# Altium schematic sheet Circuit_Design.SchDoc
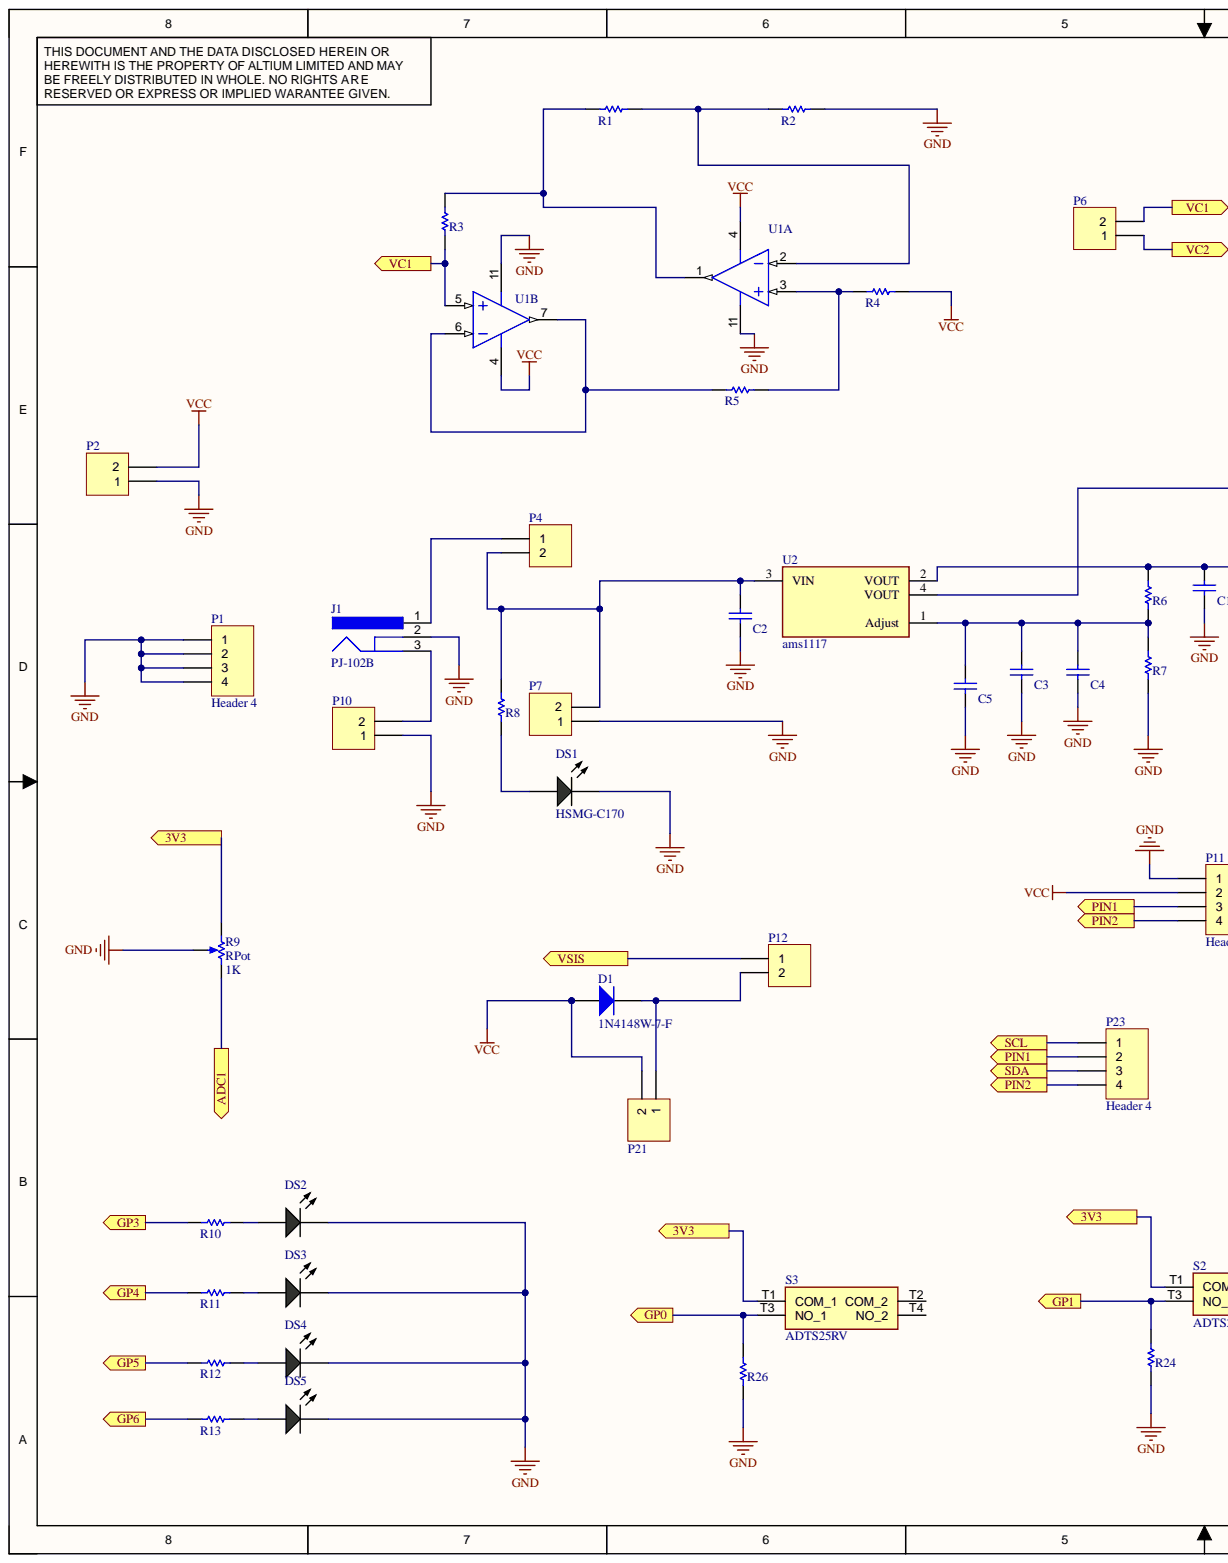
[diagram p0: Circuit_Design.SchDoc, left]
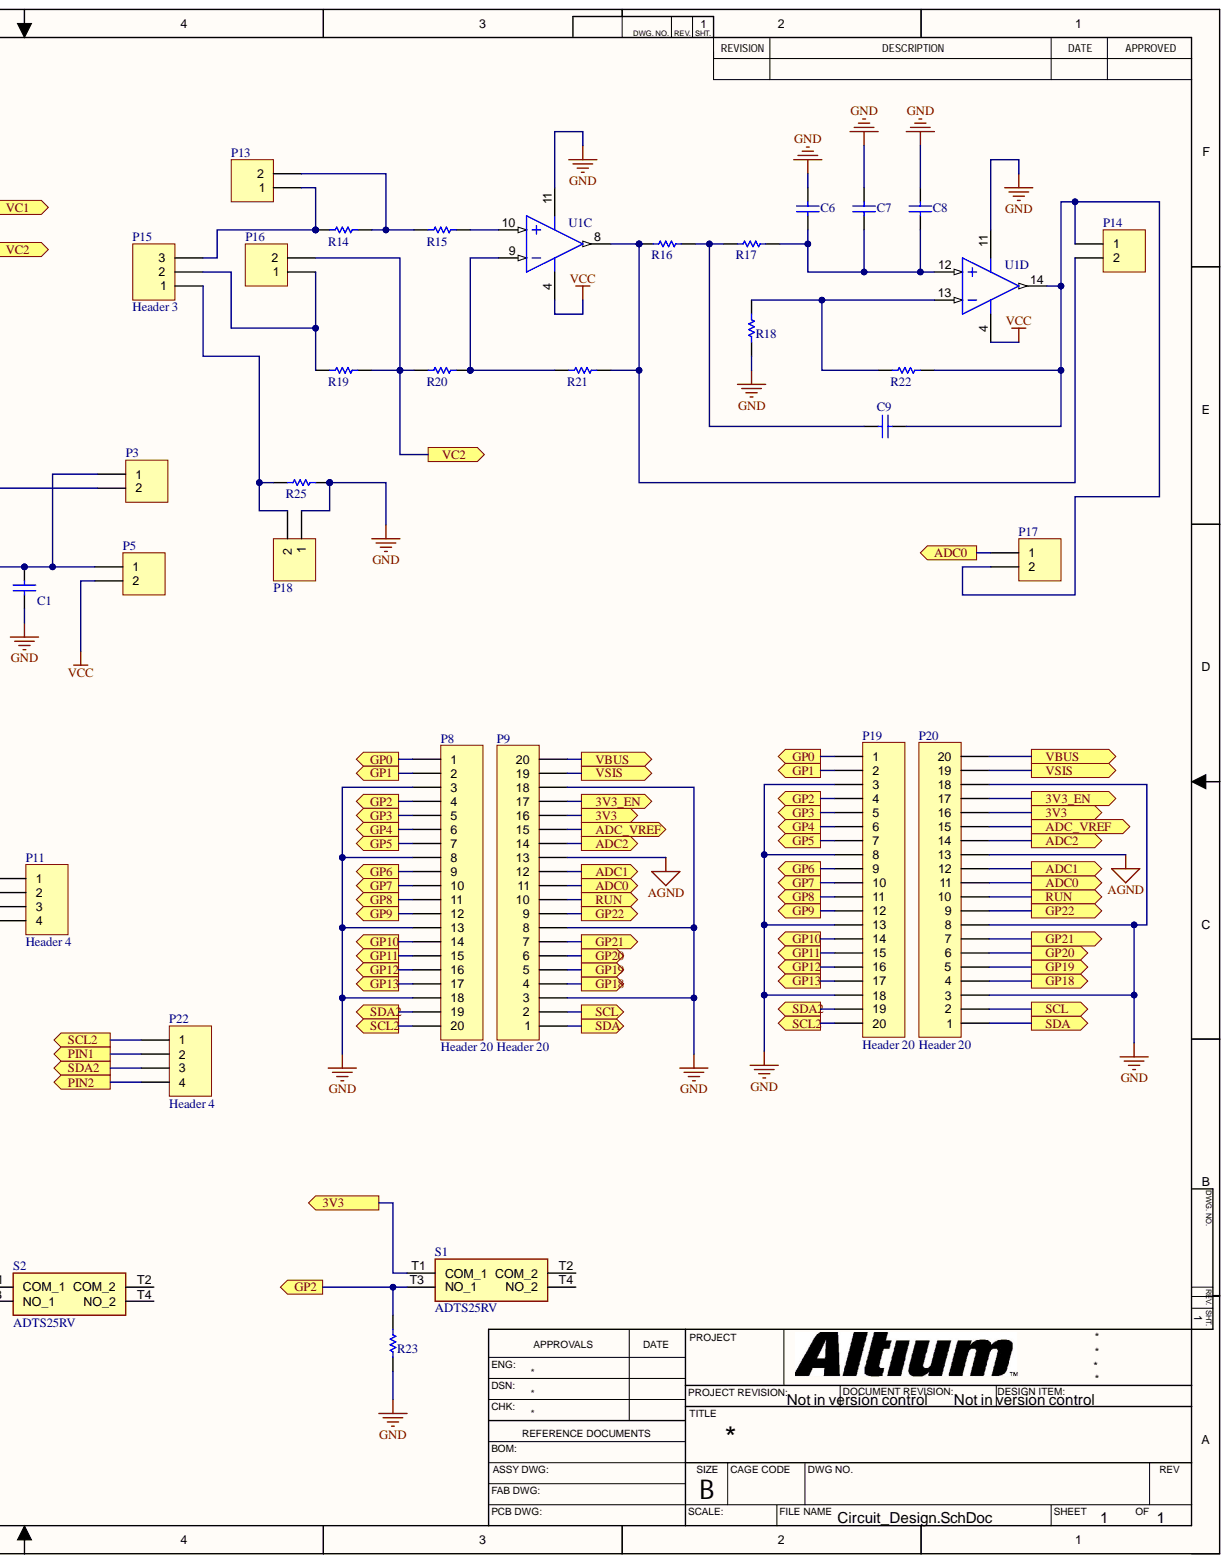
[diagram p1: Circuit_Design.SchDoc, right]

## Parts
C1  C0805C105K3RACTU  [CAPC2013X140X50LL20T25]  (value not drawn)
C2  C0805C105K3RACTU  [CAPC2013X140X50LL20T25]  (value not drawn)
C3  C0805C105K3RACTU  [CAPC2013X140X50LL20T25]  (value not drawn)
C4  C0805C105K3RACTU  [CAPC2013X140X50LL20T25]  (value not drawn)
C5  C0805C105K3RACTU  [CAPC2013X140X50LL20T25]  (value not drawn)
C6  C0805C105K3RACTU  [CAPC2013X140X50LL20T25]  (value not drawn)
C7  C0805C105K3RACTU  [CAPC2013X140X50LL20T25]  (value not drawn)
C8  C0805C105K3RACTU  [CAPC2013X140X50LL20T25]  (value not drawn)
C9  C0805C105K3RACTU  [CAPC2013X140X50LL20T25]  (value not drawn)
D1  1N4148W-7-F  [DIOD-SOD123-2_V]
DS1  HSMG-C170  [AVAG-HSMX-C170_V]
DS2  HSMG-C170  [AVAG-HSMX-C170_V]
DS3  HSMG-C170  [AVAG-HSMX-C170_V]
DS4  HSMG-C170  [AVAG-HSMX-C170_V]
DS5  HSMG-C170  [AVAG-HSMX-C170_V]
J1  PJ-102B  [CUI-PJ-102B_V]
P1  Header 4  [HDR1X4]
P2  Header 2  [HDR1X2]  (value not drawn)
P3  Header 2  [HDR1X2]  (value not drawn)
P4  Header 2  [HDR1X2]  (value not drawn)
P5  Header 2  [HDR1X2]  (value not drawn)
P6  Header 2  [HDR1X2]  (value not drawn)
P7  Header 2  [HDR1X2]  (value not drawn)
P8  Header 20  [HDR1X20]
P9  Header 20  [HDR1X20]
P10  Header 2  [HDR1X2]  (value not drawn)
P11  Header 4  [HDR1X4]
P12  Header 2  [HDR1X2]  (value not drawn)
P13  Header 2  [HDR1X2]  (value not drawn)
P14  Header 2  [HDR1X2]  (value not drawn)
P15  Header 3  [HDR1X3]
P16  Header 2  [HDR1X2]  (value not drawn)
P17  Header 2  [HDR1X2]  (value not drawn)
P18  Header 2  [HDR1X2]  (value not drawn)
P19  Header 20  [HDR1X20]
P20  Header 20  [HDR1X20]
P21  Header 2  [HDR1X2]  (value not drawn)
P22  Header 4  [HDR1X4]
P23  Header 4  [HDR1X4]
R1  CRCW08050000Z0EA  [RESC2013X50X30LL20T20]  (value not drawn)
R2  CRCW08050000Z0EA  [RESC2013X50X30LL20T20]  (value not drawn)
R3  CRCW08050000Z0EA  [RESC2013X50X30LL20T20]  (value not drawn)
R4  CRCW08050000Z0EA  [RESC2013X50X30LL20T20]  (value not drawn)
R5  CRCW08050000Z0EA  [RESC2013X50X30LL20T20]  (value not drawn)
R6  CRCW08050000Z0EA  [RESC2013X50X30LL20T20]  (value not drawn)
R7  CRCW08050000Z0EA  [RESC2013X50X30LL20T20]  (value not drawn)
R8  CRCW08050000Z0EA  [RESC2013X50X30LL20T20]  (value not drawn)
R9  RPot  value 1K  [VR5]
R10  CRCW08050000Z0EA  [RESC2013X50X30LL20T20]  (value not drawn)
R11  CRCW08050000Z0EA  [RESC2013X50X30LL20T20]  (value not drawn)
R12  CRCW08050000Z0EA  [RESC2013X50X30LL20T20]  (value not drawn)
R13  CRCW08050000Z0EA  [RESC2013X50X30LL20T20]  (value not drawn)
R14  CRCW08050000Z0EA  [RESC2013X50X30LL20T20]  (value not drawn)
R15  CRCW08050000Z0EA  [RESC2013X50X30LL20T20]  (value not drawn)
R16  CRCW08050000Z0EA  [RESC2013X50X30LL20T20]  (value not drawn)
R17  CRCW08050000Z0EA  [RESC2013X50X30LL20T20]  (value not drawn)
R18  CRCW08050000Z0EA  [RESC2013X50X30LL20T20]  (value not drawn)
R19  CRCW08050000Z0EA  [RESC2013X50X30LL20T20]  (value not drawn)
R20  CRCW08050000Z0EA  [RESC2013X50X30LL20T20]  (value not drawn)
R21  CRCW08050000Z0EA  [RESC2013X50X30LL20T20]  (value not drawn)
R22  CRCW08050000Z0EA  [RESC2013X50X30LL20T20]  (value not drawn)
R23  CRCW08050000Z0EA  [RESC2013X50X30LL20T20]  (value not drawn)
R24  CRCW08050000Z0EA  [RESC2013X50X30LL20T20]  (value not drawn)
R25  CRCW08050000Z0EA  [RESC2013X50X30LL20T20]  (value not drawn)
R26  CRCW08050000Z0EA  [RESC2013X50X30LL20T20]  (value not drawn)
S1  ADTS25RV  [ADTS25RV]
S2  ADTS25RV  [ADTS25RV]
S3  ADTS25RV  [ADTS25RV]
U1  LMV324IDR  [D0014A_V]  (value not drawn)  (parts A,B,C,D)
U2  ams1117  [FP-ams1117-IPC_C]

## Ports
3V3, 3V3_EN, ADC0, ADC1, ADC2, ADC_VREF, GP0, GP1, GP2, GP3, GP4, GP5, GP6, GP7, GP8, GP9, GP10, GP11, GP12, GP13, GP18, GP19, GP20, GP21, GP22, PIN1, PIN2, RUN, SCL, SCL2, SDA, SDA2, VBUS, VC1, VC2, VSIS

## Nets
AGND: P9.13 P20.13
GND: C1.2 C2.2 C3.2 C4.2 C5.2 C6.2 C7.2 C8.2 DS1.2 DS2.2 DS3.2 DS4.2 DS5.2 J1.2 P1.1 P1.2 P1.3 P1.4 P2.1 P7.1 P8.3 P8.8 P8.13 P8.18 P9.3 P9.8 P9.18 P10.1 P11.1 P18.1 P19.3 P19.8 P19.13 P19.18 P20.3 P20.8 P20.18 R2.2 R7.1 R9.3 R18.1 R23.1 R24.1 R25.2 R26.1 U1.11
VCC: D1.1 P2.2 P5.2 P11.2 P21.2 R4.2 U1.4
3V3: P9.16 P20.16 R9.1 S1.T1(COM_1) S2.T1(COM_1) S3.T1(COM_1) ; port 3V3
3V3_EN: P9.17 P20.17 ; port 3V3_EN
ADC0: P9.11 P17.1 P20.11 ; port ADC0
ADC1: P9.12 P20.12 R9.2 ; port ADC1
ADC2: P9.14 P20.14 ; port ADC2
ADC_VREF: P9.15 P20.15 ; port ADC_VREF
GP0: P8.1 P19.1 R26.2 S3.T3(NO_1) ; port GP0
GP1: P8.2 P19.2 R24.2 S2.T3(NO_1) ; port GP1
GP2: P8.4 P19.4 R23.2 S1.T3(NO_1) ; port GP2
GP3: P8.5 P19.5 R10.1 ; port GP3
GP4: P8.6 P19.6 R11.1 ; port GP4
GP5: P8.7 P19.7 R12.1 ; port GP5
GP6: P8.9 P19.9 R13.1 ; port GP6
GP7: P8.10 P19.10 ; port GP7
GP8: P8.11 P19.11 ; port GP8
GP9: P8.12 P19.12 ; port GP9
GP10: P8.14 P19.14 ; port GP10
GP11: P8.15 P19.15 ; port GP11
GP12: P8.16 P19.16 ; port GP12
GP13: P8.17 P19.17 ; port GP13
GP18: P9.4 P20.4 ; port GP18
GP19: P9.5 P20.5 ; port GP19
GP20: P9.6 P20.6 ; port GP20
GP21: P9.7 P20.7 ; port GP21
GP22: P9.9 P20.9 ; port GP22
PIN1: P11.3 P22.2 P23.2 ; port PIN1
PIN2: P11.4 P22.4 P23.4 ; port PIN2
RUN: P9.10 P20.10 ; port RUN
SCL: P9.2 P20.2 P23.1 ; port SCL
SCL2: P8.20 P19.20 P22.1 ; port SCL2
SDA: P9.1 P20.1 P23.3 ; port SDA
SDA2: P8.19 P19.19 P22.3 ; port SDA2
VBUS: P9.20 P20.20 ; port VBUS
VC1: P6.2 R3.1 U1.5 ; port VC1
VC2: P6.1 P16.2 R19.2 R20.1 ; port VC2
VSIS: P9.19 P12.1 P20.19 ; port VSIS
NetC1_1: C1.1 P3.1 P5.1 R6.2 U2.2(VOUT)
NetC2_1: C2.1 P4.2 P7.2 R8.1 U2.3(VIN)
NetC3_1: C3.1 C4.1 C5.1 R6.1 R7.2 U2.1(Adjust)
NetC6_1: C6.1 C7.1 C8.1 R17.2 U1.12
NetC9_1: C9.1 P14.1 P17.2 R22.2 U1.14
NetC9_2: C9.2 R16.2 R17.1
NetD1_2: D1.2 P12.2 P21.1
NetDS1_1: DS1.1 R8.2
NetDS2_1: DS2.1 R10.2
NetDS3_1: DS3.1 R11.2
NetDS4_1: DS4.1 R12.2
NetDS5_1: DS5.1 R13.2
NetJ1_1: J1.1 P4.1
NetJ1_3: J1.3 P10.2
NetP3_2: P3.2 U2.4(VOUT)
NetP13_1: P13.1 P15.3 R14.1
NetP13_2: P13.2 R14.2 R15.1
NetP14_2: P14.2 R16.1 R21.2 U1.8
NetP15_1: P15.1 P18.2 R25.1
NetP15_2: P15.2 P16.1 R19.1
NetR1_1: R1.1 R3.2 U1.1
NetR1_2: R1.2 R2.1 U1.2
NetR4_1: R4.1 R5.2 U1.3
NetR5_1: R5.1 U1.6 U1.7
NetR15_2: R15.2 U1.10
NetR18_2: R18.2 R22.1 U1.13
NetR20_2: R20.2 R21.1 U1.9

Not connected: S1 T2(COM_2) T4(NO_2); S2 T2(COM_2) T4(NO_2); S3 T2(COM_2) T4(NO_2)

## Library data of the parts
C1-C9 = CMP-2007-03234-1: Manufacturer: Kemet; Component Kind: Standard; Library Name: Altium Content Vault; Part Number: C0805C105K3RACTU
D1 = CMP-2000-04932-2: Fast Switching Diode, 100 V, 0.15 A, -65 to 150 degC, 2-Pin?SOD123, RoHS, Tape and Reel; Brand: DiodesIncorporated; Configuration: Single; If - Forward Current: 0.15A; Ir - Reverse Current: 1uA; Max Surge Current: 2A; Peak Reverse Voltage: 100V; Recovery Time: 4ns; Unit Weight: 0.000353oz; Vf - Forward Voltage: 1.25V; Component Kind: Standard; Datasheet URL: http://www.farnell.com/datasheets/1915529.pdf; Datasheet Version: Rev. 24-2, 10/2013; Factory Pack Quantity: 3000; Height: 1.25mm; Length: 2.85mm; Library Name: Altium Content Vault; Manufacturer: DiodesIncorporated; Manufacturer URL: http://www.diodes.com/; Maximum Operating Temperature: +150C; Minimum Operating Temperature: -65C; Mounting Style: SMD/SMT; Operating Temperature Range: -65Cto+150C; Package / Case: SOD-123; Package Reference: SOD123-2; Package URL: http://www.diodes.com/datasheets/ds18004.pdf; Packaging: Reel; Product: SwitchingDiodes; Product Category: Diodes-GeneralPurpose,Power,Switching; RoHS: True; Series: 1N4148; Type: SwitchingDiode; Width: 1.7mm
DS1-DS5 = CMP-2000-05062-1: Chip LED, Green, 2.2 V, -40 to 85 degC, 2-Pin SMD, Pb-Free, Tape and Reel; Manufacturer: Avago; Bulb Size: 1.25mmx1.4mm; Dominant Wavelength: 572nm; External Depth: 0.8mm; External Length / Height: 2mm; External Width: 1.25mm; Forward Current If: 20mA; Forward Voltage: 2.2V; Forward Voltage VF Max: 2.6V; LED Type: Standard; Lens Shape: Rectangular; Light Area Height: 1mm; Luminous Intensity: 15mcd; Luminous Intensity Min: 4mcd; No. of Pins: 2; Opto Mounting Orientation: Top; Peak Wavelength: 570nm; PIV Max: 5V; SVHC: NoSVHC(16-Jun-2014); Viewing Angle: 170deg; Component Kind: Standard; Datasheet URL: https://datasheet.ciiva.com/29280/180495-da-01-en-chip-led-hsmg-c170-gelb-gruen-29280971.pdf; Datasheet Version: Oct-08; Dominant Wavelength Typ: 572nm; Forward Current If Max: 20mA; LED Colour: Green; LED Mounting: SMD; Library Name: Altium Content Vault; Luminous Intensity Typ: 15mcd; Manufacturer URL: http://www.avagotech.com/; Mounting Type: SMD; MSL: MSL2A-4weeks; Operating Temperature Max: 85degC; Operating Temperature Min: -30degC; Operating Temperature Range: -30degCto+85degC; Opto Case Style: SMD; Package Description: 2-Pin Surface Mount Device, Body 2 x 1.25 mm, Height 0.8 mm; Package Reference: HSMX-C170; Packaging: CutTape; Peak Forward Current: 20mA; Storage Temperature Max: 85degC; Storage Temperature Min: -40degC; Voltage Vf Typ: 2.2V; Wavelength Typ: 570nm
J1 = CMP-2000-06900-1: Through Hole Right Angle DC Power Jack, 2.5 A, 2.5 mm Center Pin, 3 Position, -25 to 85 degC, RoHS, Bulk; Manufacturer: CUIInc.; Brand: CUI; Current Rating: 2.5A; Inside Contact Diameter: 2.5mm; Insulation Resistance: 100MOhms; Outside Contact Diameter: 6.5mm; Voltage Rating: 24V; Component Kind: Standard; Connector Type: DCPowerJack; Contact Gender: Male; Contact Material: Copper; Contact Plating: Nickel; Datasheet URL: http://www.cui.com/product/resource/pj-102b.pdf; Datasheet Version: Rev. 1.03, 04/2016; Factory Pack Quantity: 280; Flammability Rating: UL94V-0; Housing Material: PolybutyleneTerephthalate(PBT); Library Name: Altium Content Vault; Manufacturer URL: http://www.cui.com/; Mounting Angle: Right; Mounting Style: ThroughHole; Mounting Technology: ThroughHole; Operating Temperature Range: -25Cto+85C; Package Description: 3-Pin Through Hole Device, Body 14.4 x 9 mm, Height 11 mm; Package Reference: PJ-102B; Packaging: Bulk; Product: Jacks; Product Category: DCPowerConnectors; RoHS: True; Termination Style: ThroughHole
P1 P11 P22 P23 = Header 4: Header, 4-Pin; Component Kind: Standard; LatestRevisionDate: 17-Jul-2002; LatestRevisionNote: Re-released for DXP Platform.; Library Name: Miscellaneous Connectors.IntLib; Signal Integrity: Connector; Publisher: Altium Limited
P2-P7 P10 P12-P14 P16-P18 P21 = Header 2: Header, 2-Pin; Component Kind: Standard; LatestRevisionDate: 17-Jul-2002; LatestRevisionNote: Re-released for DXP Platform.; Library Name: Miscellaneous Connectors.IntLib; Signal Integrity: Connector; Publisher: Altium Limited
P8 P9 P19 P20 = Header 20: Header, 20-Pin; Component Kind: Standard; LatestRevisionDate: 17-Jul-2002; LatestRevisionNote: Re-released for DXP Platform.; Library Name: Miscellaneous Connectors.IntLib; Signal Integrity: Connector; Publisher: Altium Limited
P15 = Header 3: Header, 3-Pin; Component Kind: Standard; LatestRevisionDate: 17-Jul-2002; LatestRevisionNote: Re-released for DXP Platform.; Library Name: Miscellaneous Connectors.IntLib; Signal Integrity: Connector; Publisher: Altium Limited
R1-R8 R10-R26 = CMP-2001-04896-1: Manufacturer: Vishay Dale; Component Kind: Standard; Library Name: Altium Content Vault; Part Number: CRCW08050000Z0EA
R9 = RPot: Potentiometer; Set Position: 0.5; Sim Note: Enter the Resistance value and the set point into parameter fields.; Component Kind: Standard; LatestRevisionDate: 17-Jul-2002; LatestRevisionNote: Re-released for DXP Platform.; Library Name: Miscellaneous Devices.IntLib; PackageDescription: Variable Resistor, Thru-Hole; 3 Leads; PackageReference: VR5; Published: 8-Jun-2000; Simulation: POT; Publisher: Altium Limited
S1-S3 = ADTS25RV: Switch; Manufacturer_Name: Apem; Component Kind: Standard; Datasheet Link: https://media.digikey.com/pdf/Data%20Sheets/APEM%20Components%20PDFs/ADTS6,%20ADTSM,%20AKTSC6%20Series.pdf; Height: 9.5mm; Manufacturer_Part_Number: ADTS25RV; Mouser Part Number: 642-ADTS25RV; Mouser Price/Stock: https://www.mouser.co.uk/ProductDetail/Apem/ADTS25RV?qs=ikKlZSMjbcRDCzQ0CyZHnw%3D%3D
U1 = CMP-1685-00010-1: Quad Low-Voltage Rail-to-Rail Output Operational Amplifier, 2.7 to 5.5 V, -40 to 125 degC, 14-Pin SOIC (D), Green (RoHS & no Sb/Br), Tape and Reel; Code_JEDEC: MS-012; Component Kind: Standard; ComponentLink1Description: Manufacturer URL; ComponentLink1URL: http://www.ti.com/; ComponentLink2Description: Datasheet; ComponentLink2URL: http://www.ti.com/lit/ds/symlink/lmv324.pdf; ComponentLink3Description: Package Specification; ComponentLink3URL: http://www.ti.com/lit/ml/mpds177g/mpds177g.pdf; DatasheetVersion: SLOS263W, 10/2014; Library Name: Altium Content Vault; PackageDescription: 14-Pin Small Outline Integrated Circuit, Body 8.65 x 3.9 mm, Pitch 1.27 mm; PackageReference: D0014A
U2 = CMP-209535-000002-1: 1A LOW DROPOUT VOLTAGE REGULATOR; Component Kind: Standard; Library Name: Altium Content Vault; RoHS Compliant: Yes
